# Altium schematic sheet MCU.SchDoc(MCU)
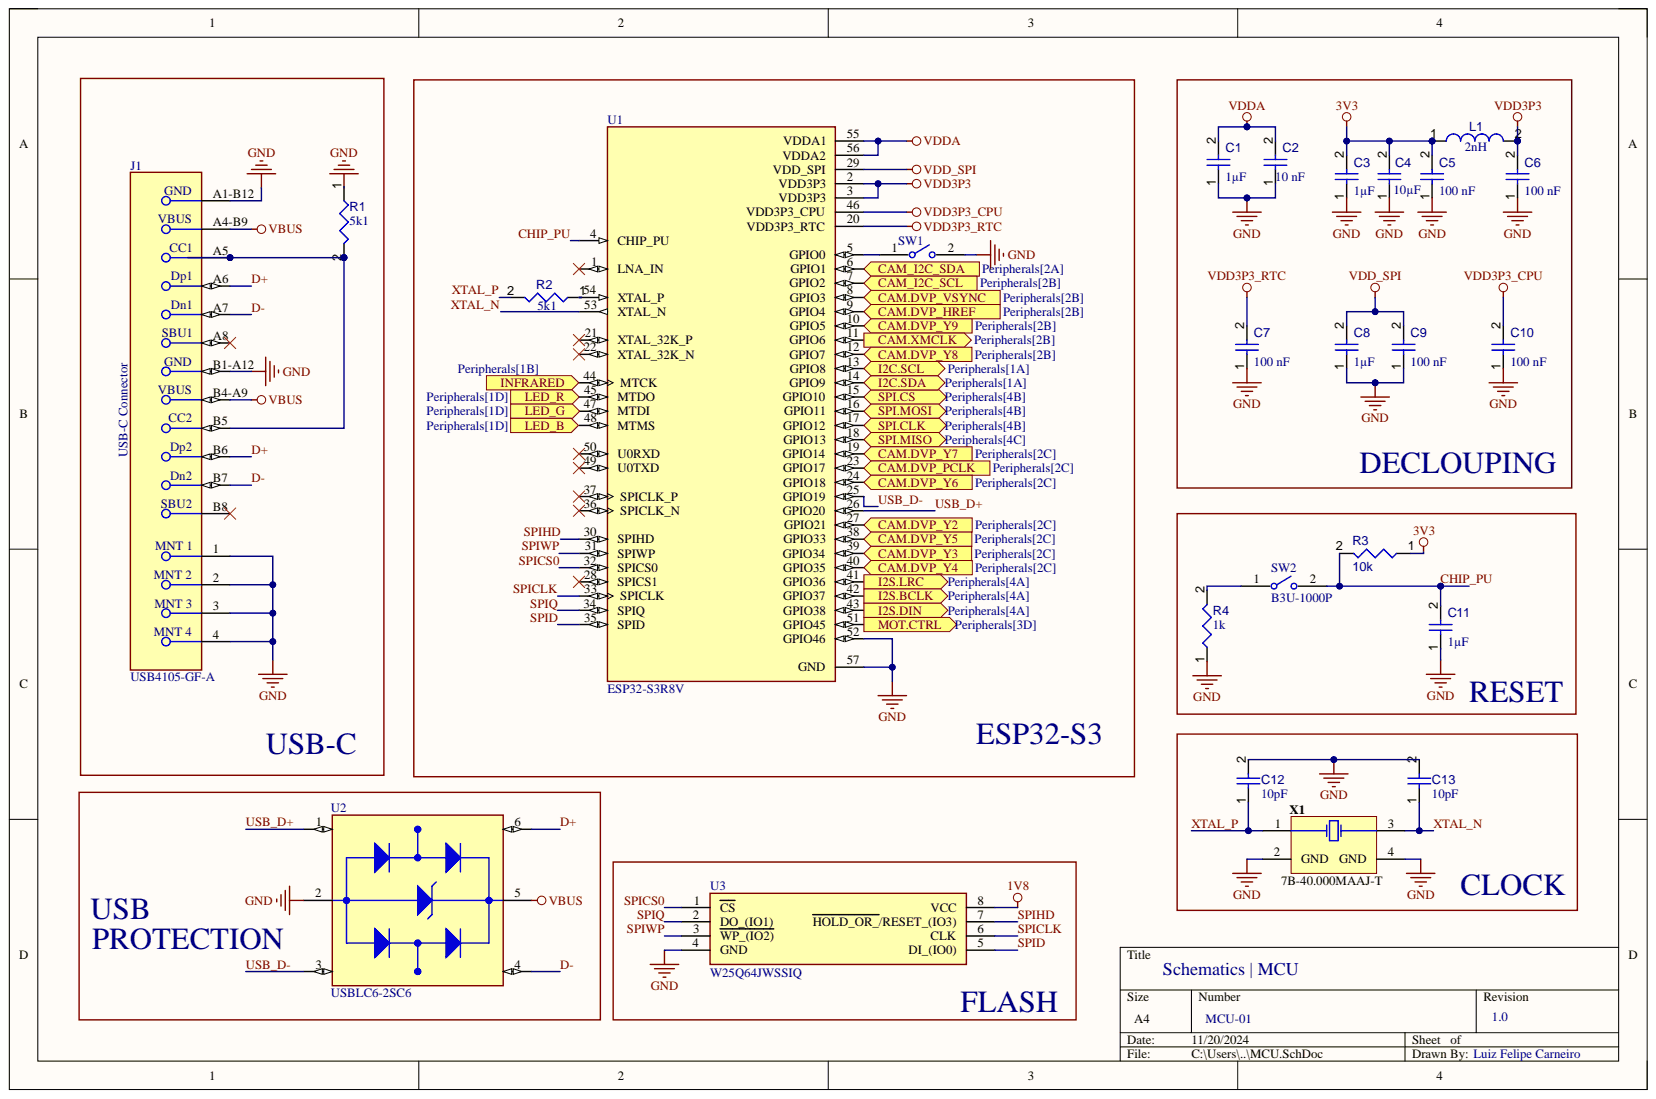
[diagram p0: MCU.SchDoc]

## Parts
C1  value 1µF  [CAPC0603(1608)100_L]  (hidden comment: Capacitor 1µF +/-40% 50V 0603)
C2  value 10 nF  [CAPC1608X06N]  (hidden comment: KAM15AR71E104KT)
C3  value 1µF  [CAPC0603(1608)100_L]  (hidden comment: Capacitor 1µF +/-40% 50V 0603)
C4  value 10µF  [CAPC2013X135X45NL10T25]  (hidden comment: Capacitor 10 uF +/- 10% 16 V 0805)
C5  value 100 nF  [CAPC1608X06N]  (hidden comment: KAM15AR71E104KT)
C6  value 100 nF  [CAPC1608X06N]  (hidden comment: KAM15AR71E104KT)
C7  value 100 nF  [CAPC1608X06N]  (hidden comment: KAM15AR71E104KT)
C8  value 1µF  [CAPC0603(1608)100_L]  (hidden comment: Capacitor 1µF +/-40% 50V 0603)
C9  value 100 nF  [CAPC1608X06N]  (hidden comment: KAM15AR71E104KT)
C10  value 100 nF  [CAPC1608X06N]  (hidden comment: KAM15AR71E104KT)
C11  value 1µF  [CAPC0603(1608)100_L]  (hidden comment: Capacitor 1µF +/-40% 50V 0603)
C12  value 10pF  [CAPC0402(1005)60_L]  (hidden comment: Capacitor 47nF +/-20% 50V 0402)
C13  value 10pF  [CAPC0402(1005)60_L]  (hidden comment: Capacitor 47nF +/-20% 50V 0402)
J1  USB4105-GF-A  [FP-USB4105-GF-A-MFG]
L1  value 2nH  [IND1005X55X20VL5T10]  (hidden comment: ELJ-RF2N2DFB)
R1  value 5k1  [RESC0402(1005)_L]  (hidden comment: Resistor 1K +/-5 % 0402 63 mW)
R2  value 5k1  [RESC0402(1005)_L]  (hidden comment: Resistor 1K +/-5 % 0402 63 mW)
R3  10k  [RESC3216X06N]
R4  value 1k  [RESC0402(1005)_L]  (hidden comment: Resistor 1K +/-5 % 0402 63 mW)
SW1  B3U-1000P  [FP-B3U-1000P-MFG]
SW2  B3U-1000P  [FP-B3U-1000P-MFG]
U1  ESP32-S3R8V  [QFN40P700X700X90-57N]
U2  USBLC6-2SC6  [SOT95P280X145-6N]
U3  W25Q64JWSSIQ  [SOIC127P790X216-8N]
X1  7B-40.000MAAJ-T  [TXC-7B-4_V]

## Ports
CAM.DVP_HREF, CAM.DVP_PCLK, CAM.DVP_VSYNC, CAM.DVP_Y2, CAM.DVP_Y3, CAM.DVP_Y4, CAM.DVP_Y5, CAM.DVP_Y6, CAM.DVP_Y7, CAM.DVP_Y8, CAM.DVP_Y9, CAM.XMCLK, CAM_I2C_SCL, CAM_I2C_SDA, I2C.SCL, I2C.SDA, I2S.BCLK, I2S.DIN, I2S.LRC, INFRARED, LED_B, LED_G, LED_R, MOT.CTRL, SPI.CLK, SPI.CS, SPI.MISO, SPI.MOSI

## Nets
1V8: U3.8(VCC)
3V3: C3.2 C4.2 C5.2 L1.1 R3.1
GND: C1.1 C2.1 C3.1 C4.1 C5.1 C6.1 C7.1 C8.1 C9.1 C10.1 C11.1 C12.2 C13.2 J1.1 J1.2 J1.3 J1.4 J1.A1-B12 J1.B1-A12 R1.1 R4.1 SW1.2 U1.52(GPIO46) U1.57(GND) U2.2 U3.4(GND) X1.2(GND) X1.4(GND)
VBUS: J1.A4-B9 J1.B4-A9 U2.5
VDD3P3: C6.2 L1.2 U1.2(VDD3P3) U1.3(VDD3P3)
VDD3P3_CPU: C10.2 U1.46(VDD3P3_CPU)
VDD3P3_RTC: C7.2 U1.20(VDD3P3_RTC)
VDD_SPI: C8.2 C9.2 U1.29(VDD_SPI)
VDDA: C1.2 C2.2 U1.55(VDDA1) U1.56(VDDA2)
CAM.DVP_HREF: U1.9(GPIO4) ; port CAM.DVP_HREF
CAM.DVP_PCLK: U1.23(GPIO17) ; port CAM.DVP_PCLK
CAM.DVP_VSYNC: U1.8(GPIO3) ; port CAM.DVP_VSYNC
CAM.DVP_Y2: U1.27(GPIO21) ; port CAM.DVP_Y2
CAM.DVP_Y3: U1.39(GPIO34) ; port CAM.DVP_Y3
CAM.DVP_Y4: U1.40(GPIO35) ; port CAM.DVP_Y4
CAM.DVP_Y5: U1.38(GPIO33) ; port CAM.DVP_Y5
CAM.DVP_Y6: U1.24(GPIO18) ; port CAM.DVP_Y6
CAM.DVP_Y7: U1.19(GPIO14) ; port CAM.DVP_Y7
CAM.DVP_Y8: U1.12(GPIO7) ; port CAM.DVP_Y8
CAM.DVP_Y9: U1.10(GPIO5) ; port CAM.DVP_Y9
CAM.XMCLK: U1.11(GPIO6) ; port CAM.XMCLK
CAM_I2C_SCL: U1.7(GPIO2) ; port CAM_I2C_SCL
CAM_I2C_SDA: U1.6(GPIO1) ; port CAM_I2C_SDA
CHIP_PU: C11.2 R3.2 SW2.2 U1.4(CHIP_PU)
D+: J1.A6 J1.B6 U2.6
D-: J1.A7 J1.B7 U2.4
I2C.SCL: U1.13(GPIO8) ; port I2C.SCL
I2C.SDA: U1.14(GPIO9) ; port I2C.SDA
I2S.BCLK: U1.42(GPIO37) ; port I2S.BCLK
I2S.DIN: U1.43(GPIO38) ; port I2S.DIN
I2S.LRC: U1.41(GPIO36) ; port I2S.LRC
MOT.CTRL: U1.51(GPIO45) ; port MOT.CTRL
SPI.CLK: U1.17(GPIO12) ; port SPI.CLK
SPI.CS: U1.15(GPIO10) ; port SPI.CS
SPI.MISO: U1.18(GPIO13) ; port SPI.MISO
SPI.MOSI: U1.16(GPIO11) ; port SPI.MOSI
SPICLK: U1.33(SPICLK) U3.6(CLK)
SPICS0: U1.32(SPICS0) U3.1(CS)
SPID: U1.35(SPID) U3.5(DI_(IO0))
SPIHD: U1.30(SPIHD) U3.7(HOLD_OR_/RESET_(IO3))
SPIQ: U1.34(SPIQ) U3.2(DO_(IO1))
SPIWP: U1.31(SPIWP) U3.3(WP_(IO2))
USB_D+: U1.26(GPIO20) U2.1
USB_D-: U1.25(GPIO19) U2.3
XTAL_N: C13.1 U1.53(XTAL_N) X1.3
XTAL_P: C12.1 R2.2 X1.1
NetJ1_A5: J1.A5 J1.B5 R1.2
NetR2_1: R2.1 U1.54(XTAL_P)
NetR4_2: R4.2 SW2.1
NetSW1_1: SW1.1 U1.5(GPIO0)
N00001: ; port LED_B
N00002: ; port LED_G
N00003: ; port LED_R
N00004: ; port INFRARED

Not connected: J1 A8 B8; U1 1(LNA_IN) 21(XTAL_32K_P) 22(XTAL_32K_N) 28(SPICS1) 36(SPICLK_N) 37(SPICLK_P) 44(MTCK) 45(MTDO) 47(MTDI) 48(MTMS) 49(U0TXD) 50(U0RXD)

## Library data of the parts
C1 C3 C8 C11 = CMP-001-00078-4: Chip Capacitor, 1µF +/-40%, 50V, 0603, Thickness 1 mm; Tolerance: 10%; Case/Package: 0603; Component Kind: Standard; Max Operating Temperature: 85°C; Min Operating Temperature: -55°C; Mounting Technology: SMT; Pins: 2; Voltage Rating: 50V; Library Name: EPTA Workspace
C2 = CMP-001-00083-2: Cap Cer 0.01UF 100V X7R 0805; Component Kind: Standard; Library Name: EPTA Workspace
C4 = CMP-001-00015-6: Chip Capacitor, 10 uF, +/- 10%, 16 V, 0805 (2012 Metric); Capacitor Type: Ceramic; Voltage Rating: 16V; Case/Package: 0805; Component Kind: Standard; Max Operating Temperature: 85°C; Min Operating Temperature: -55°C; Mounting Technology: SM; Pins: 2; RoHS Compliant: True; Tolerance: 10%; Library Name: HW/FW IoT
C5-C7 C9 C10 = CMP-001-00083-2: Cap Cer 0.1UF 25V X7R 0603; Component Kind: Standard; Library Name: EPTA Workspace
C12 C13 = CMP-001-00071-4: Chip Capacitor, 47nF +/-20%, 50V, 0402, Thickness 0.6 mm; Tolerance: 20%; Case/Package: 0402; Component Kind: Standard; Max Operating Temperature: 85°C; Min Operating Temperature: -55°C; Mounting Technology: SMT; Pins: 2; Voltage Rating: 50V; Library Name: HW/FW IoT
J1 = CMP-245163-000001-1: CONN RCPT USB2.0 TYPEC 5A; Manufacturer: GCT; Conn Orientation: Right Angle; Current Rating: 5/6.25/1.25/0.25A; Is Female: yes; Number Of Contacts: 16; Voltage Rating: 20V; Automotive: No; Category: Conn; Component Kind: Standard; ComponentLink2Description: Datasheet; ComponentLink2URL: https://gct.co/files/drawings/usb4105.pdf; Contact Material: Gold,Nickel; Device Class L1: Connectors; Device Class L2: USB Connectors; Device Class L3: unset; Digikey Description: CONN RCPT USB2.0 TYPEC 5A; Height: 3.46mm; Lead Free: Yes; Library Name: Altium Content Vault; Manufacturer Part Number: USB4105-GF-A; Octopart: https://octopart.com/usb4105-gf-a-gct-106627878; Package: 0; RoHS: TRUE; Temperature: -40°C to +85°C; Temperature Range High: +85°C; Temperature Range Low: -40°C; Usb Standard: USB 2.0
L1 = CMP-006-00006-6: Chip Inductor, 2.2 nH, +/- 0.3 nH, 400 mA, -40 to 85 degC, 0402 (1005 Metric), RoHS, Tape and Reel; Manufacturer: Panasonic; Core Material: Ceramic; Current Rating: 400mA; Q Factor: 8; Shielding: Unshielded; Tolerance: 5%; Case/Package: 0402; Component Kind: Standard; Datasheet URL: http://www.bourns.com/docs/Product-Datasheets/cm322522.pdf; Datasheet Version: Sep-12; Max Operating Temperature: 85°C; Min Operating Temperature: -20°C; Mounting Technology: SM; Package Description: 2-Pin Surface Mount Device, Body 1 x 0.5 mm; Package Quantity: 10000; Package Reference: 0402; Packaging: TapeandReel; RoHS Compliant: True; Library Name: HW/FW IoT
R1 R2 R4 = CMP-009-00157-3: Chip Resistor, 1 kOhms, +/-5 %, 63 mW, -55 to 155 degC, 0402; Power: 63mW; Tolerance: 5%; Case/Package: 0402; Component Kind: Standard; Max Operating Temperature: 155°C; Min Operating Temperature: -55°C; Pins: 2; Library Name: EPTA Workspace
R3 = CMP-009-00177-1: 1206 Thin Film, 0.1 Percentage, 25Ppm, 10Kohm Rohs Compliant: Yes; Component Kind: Standard; Library Name: EPTA Workspace
SW1 SW2 = CMP-2100-03586-2: SWITCH TACTILE SPST-NO 0.05A 12V; Actuator Length: 0.4mm; Actuator Type: Standard; Contact Current Rating: 50mA; Contact Resistance: 100mR; Electrical Life: 200000Cycles; Illumination Type: Non-Illuminated; Operating Force: 1.5N; Ratings: Dust Proof; Switch Function: Off-Mom; Switch Travel: 0.15mm; Throw Configuration: SPST; Component Kind: Standard; Contact Material: Silver; Current Rating: 50mA; Depth: 2.5mm; Height: 1.2mm; Insulation Resistance: 100MR; Lead Free: Lead Free; Length: 4mm; Library Name: Altium Content Vault; Max Current Rating: 50mA; Max Operating Temperature: 70°C; Max Voltage Rating (DC): 12V; Mechanical Life: 200000Cycles; Min Operating Temperature: -25°C; Mount: Surface Mount; Orientation: Straight; Package Quantity: 1; Packaging: Tape and Reel; Termination: SMD/SMT; Voltage Rating (DC): 12V; Weight: 0.000776oz; Width: 3mm
U1 = CMP-031-00006-1: IC RF TxRx + MCU Bluetooth, WiFi 802.11b/g/n, Bluetooth v5.0 2.412GHz ~ 2.484GHz 56-VFQFN Exposed Pad; MF: Espressif Systems; Case/Package: None; Check_prices: https://www.snapeda.com/parts/ESP32-S3R8V/Espressif+Systems/view-part/?ref=eda; Component Kind: Standard; Component Type: Standard; Design Item ID: ESP32-S3R8V; MP: ESP32-S3R8V; Pins: 57; SnapEDA_Link: https://www.snapeda.com/parts/ESP32-S3R8V/Espressif+Systems/view-part/?ref=snap; Source: ESP32-S3R8V.SchLib; Library Name: Luiz Felipe Carneiro De Oliveira
U2 = CMP-004-00031-1: 17V Clamp 5A (8/20Âµs) Ipp Tvs Diode Surface Mount SOT-23-6; MF: STMicroelectronics; Availability: In Stock; Case/Package: SOT-23-6 STMicroelectronics; Check_prices: https://www.snapeda.com/parts/USBLC6-2SC6/STMicroelectronics/view-part/?ref=eda; Component Kind: Standard; Component Type: Standard; Design Item ID: USBLC6-2SC6; MP: USBLC6-2SC6; Pins: 6; Price: None; Purchase-URL: https://pricing.snapeda.com/search/part/USBLC6-2SC6/?ref=eda; SnapEDA_Link: https://www.snapeda.com/parts/USBLC6-2SC6/STMicroelectronics/view-part/?ref=snap; Source: USBLC6-2SC6.SchLib; Library Name: HW/FW IoT
U3 = W25Q64JWSSIQ: Integrated Circuit; Manufacturer_Name: Winbond; Arrow Part Number: W25Q64JWSSIQ; Arrow Price/Stock: https://www.arrow.com/en/products/w25q64jwssiq/winbond-electronics?region=nac; Component Kind: Standard; Datasheet Link: https://www.winbond.com/hq/search-resource-file.jsp?partNo=W25Q64JWSSIQ&type=datasheet; Height: 2.16mm; Manufacturer_Part_Number: W25Q64JWSSIQ; Mouser Part Number: 454-W25Q64JWSSIQ; Mouser Price/Stock: https://www.mouser.co.uk/ProductDetail/Winbond/W25Q64JWSSIQ?qs=YwPsRIUVAOfs3jrAZG2yJQ%3D%3D
X1 = CMP-003-00012-2: 9B Series Quartz Crystal, 40 MHz, +/-30 ppm, 18 pF, -40 to 85 degC, 4-Pin SMD, RoHS, Tape and Reel; Manufacturer: TXC; ESR: 40; Frequency: 40MHz; Frequency Tolerance: 30ppm; Load Capacitance: 18pF; Resistance: 40Ohm; Case/Package: 7B-4; Component Kind: Standard; Datasheet URL: http://www.txccrystal.com/images/pdf/7b.pdf; Datasheet Version: Jan-10; Max Operating Temperature: 70°C; Min Operating Temperature: -20°C; Mounting Technology: SM; Package Description: 4-Pin Surface Mount Device, Body 5 x 3.2 mm, Pitch 3.7 mm; Package Quantity: 1; Package Reference: 7B-4; Packaging: TapeandReel; Pins: 4; RoHS Compliant: True; Tolerance: 30ppm; Library Name: HW/FW IoT
